# Altium schematic sheet Power.SchDoc(Power)
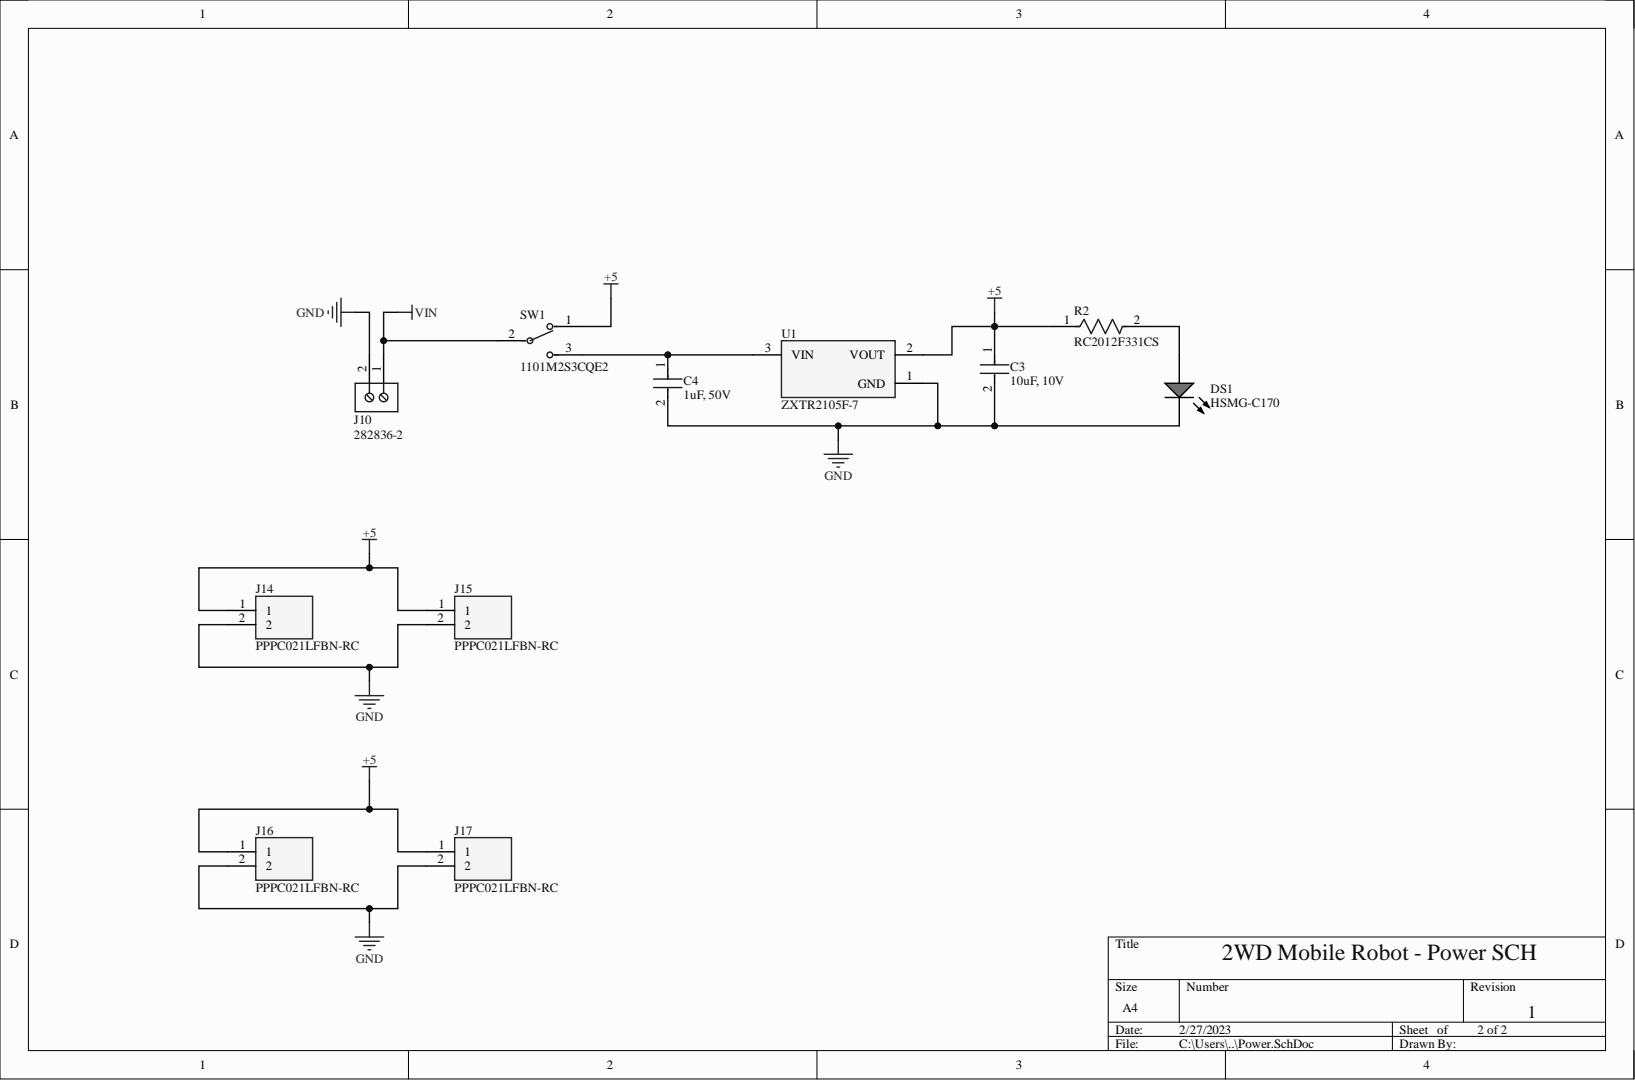
[diagram p0: Power.SchDoc]

## Parts
C3  10uF, 10V  [FP-C0805C-DG-MFG]
C4  1uF, 50V  [FP-C2012-125-0_2-IPC_A]
DS1  HSMG-C170  [AVAG-HSMX-C170_V]
J10  282836-2  [TECO-282836-2_V]
J14  PPPC021LFBN-RC  [RHDR2W80P0X254_1X2_558X254X850P]
J15  PPPC021LFBN-RC  [RHDR2W80P0X254_1X2_558X254X850P]
J16  PPPC021LFBN-RC  [RHDR2W80P0X254_1X2_558X254X850P]
J17  PPPC021LFBN-RC  [RHDR2W80P0X254_1X2_558X254X850P]
R2  RC2012F331CS  [FP-RC2012-MFG]
SW1  1101M2S3CQE2  [FP-1101M2S3CQE2-MFG]
U1  ZXTR2105F-7  [FP-SOT23-MFG]

## Nets
+5: C3.1 J14.1 J15.1 J16.1 J17.1 R2.1 SW1.1 U1.2
GND: C3.2 C4.2 DS1.2 J10.2 J14.2 J15.2 J16.2 J17.2 U1.1
VIN: J10.1 SW1.2
NetC4_1: C4.1 SW1.3 U1.3
NetDS1_1: DS1.1 R2.2

## Library data of the parts
C3 = CMP-2007-03243-2: CAP CER 10UF 10V X5R 0805; 3DS Part Number: 220-00001; Manufacturer: Kemet; Manufacturer Part Number: C0805C106K8PACTU; Manufacturer Product Number: C0805C106K8PAC7800; Material: Ceramic; Temperature Coefficient: 15%; Tolerance: 10%; Automotive: No; Capacitance: 10uF; Category: Cap; Component Kind: Standard; ComponentLink2Description: Datasheet; ComponentLink2URL: https://content.kemet.com/datasheets/KEM_C1006_X5R_SMD.pdf; Device Class L1: Passive Components; Device Class L2: Capacitors; Device Class L3: Ceramic Capacitors; Digikey Description: CAP CER 10UF 10V X5R 0805; Height: 1.4mm; Ipc Land Pattern Name: CAPC200125X125; Lead Free: Yes; Library Name: Altium Content Vault; Mouser Description: CAP CER 10UF 10V X5R 0805; Octopart: https://octopart.com/c0805c106k8pactu-kemet-98697; Package: C0805C-DG; RoHS: TRUE; Temperature: -55°C to +85°C; Temperature Characteristic: X5R; Temperature Range High: +85°C; Temperature Range Low: -55°C; Value: 10uF; Voltage: 10V; Voltage Rating: 10V
C4 = CMP-08246-005414-1: Multilayer Ceramic Capacitors 1μF ±10% 50V JB SMD 0805; Manufacturer Product Number: C2012JB1H105K125AB; Capacitance: 1uF; Case Code (Imperial): 0805; Case Code (Metric): 2012; Case/Package: 0805; Component Kind: Standard; Dielectric Material: Ceramic; Height: 1.25mm; Lead Free: Lead Free; Length: 0.079inch; Library Name: Altium Content Vault; Max Operating Temperature: 85°C; Min Operating Temperature: -25°C; Mount: Surface Mount; Package Quantity: 2000; Packaging: Tape and Reel; Resistor Type: Ceramic; RoHS Compliant: Yes; Series: C; Termination: SMD/SMT; Thickness: 0.057inch; Tolerance: 10%; Voltage Rating: 50V; Voltage Rating (DC): 50V; Width: 0.049inch
DS1 = CMP-2000-05062-1: Chip LED, Green, 2.2 V, -40 to 85 degC, 2-Pin SMD, Pb-Free, Tape and Reel; Manufacturer: Avago; Bulb Size: 1.25mmx1.4mm; Dominant Wavelength: 572nm; External Depth: 0.8mm; External Length / Height: 2mm; External Width: 1.25mm; Forward Current If: 20mA; Forward Voltage: 2.2V; Forward Voltage VF Max: 2.6V; LED Type: Standard; Lens Shape: Rectangular; Light Area Height: 1mm; Luminous Intensity: 15mcd; Luminous Intensity Min: 4mcd; No. of Pins: 2; Opto Mounting Orientation: Top; Peak Wavelength: 570nm; PIV Max: 5V; SVHC: NoSVHC(16-Jun-2014); Viewing Angle: 170deg; Component Kind: Standard; Datasheet URL: https://datasheet.ciiva.com/29280/180495-da-01-en-chip-led-hsmg-c170-gelb-gruen-29280971.pdf; Datasheet Version: Oct-08; Dominant Wavelength Typ: 572nm; Forward Current If Max: 20mA; LED Colour: Green; LED Mounting: SMD; Library Name: Altium Content Vault; Luminous Intensity Typ: 15mcd; Manufacturer URL: http://www.avagotech.com/; Mounting Type: SMD; MSL: MSL2A-4weeks; Operating Temperature Max: 85degC; Operating Temperature Min: -30degC; Operating Temperature Range: -30degCto+85degC; Opto Case Style: SMD; Package Description: 2-Pin Surface Mount Device, Body 2 x 1.25 mm, Height 0.8 mm; Package Reference: HSMX-C170; Packaging: CutTape; Peak Forward Current: 20mA; Storage Temperature Max: 85degC; Storage Temperature Min: -40degC; Voltage Vf Typ: 2.2V; Wavelength Typ: 570nm
J10 = CMP-1684-00017-1: Female Header, Pitch 5 mm, 1 x 2 Position, Height 10 mm, Tail Length 3.5 mm, Rohs, Bulk; Manufacturer: TE Connectivity; 3D Model: 282836-2.pdf; Clamp Material - Plating: Brass-NickelPlated; Current: 13.5A; Dynamic Catalog: BuchananSeries; Featured Product: EurostyleTerminalBlockConnectors; Mating Orientation: HorizontalwithBoard; Number of Levels: 1; Other Names: 5ULV-02B  A98076; Pitch: 0.197"(5.00mm); Positions Per Level: 2; Product Training Modules: Wire-to-BoardEurostyleTerminalBlocks; Screw Thread: M3; Torque - Screw: 0.68Nm(6Lb-In); Voltage: 300V; Wire Gauge: 16-30AWG; Wire Termination: Screw-RisingCageClamp; Category: Connectors,Interconnects; Color: Green; Component Kind: Standard; Contact Material - Plating: Brass-TinPlated; Datasheet URL: http://www.te.com.cn/commerce/DocumentDelivery/DDEController?Action=showdoc&DocId=Catalog+Section%7F1308389_EUROSTYLE_TERMINAL_BLOCKS%7F0607%7Fpdf%7FEnglish%7FENG_CS_1308389_EUROSTYLE_TERMINAL_BLOCKS_0607.pdf%7F282836-2; Family: TerminalBlocks-WiretoBoard; Features: Interlocking(Side); Housing Material: Polyamide(PA66),Nylon6/6; Library Name: Altium Content Vault; Manufacturer URL: http://www.te.com; Mounting Type: ThroughHole; Package Description: Female Header, Pitch 5 mm, 1 x 2 Position, Height 10 mm, Tail Length 3.5 mm; Package Reference: THD; Packaging: Bulk; RoHS Information: 282836-2StatementofCompliance; Screw Material - Plating: Brass-NickelPlated; Series: Buchanan; Standard Package: 300
J14-J17 = PPPC021LFBN-RC: Connector; Manufacturer_Name: Sullins; Component Kind: Standard; Datasheet Link: http://www.sullinscorp.com/drawings/78_P(N)PxCxxxLFBN-RC,_10492-H.pdf; Height: 8.5mm; Manufacturer_Part_Number: PPPC021LFBN-RC
R2 = CMP-13261-000745-1: Res Thick Film 0805 330Ω 1% 0.125W ±100ppm/°C Molded SMD T/R; Max Power Dissipation: 125mW; Resistance: 330R; Voltage Rating (DC): 150V; Case Code (Imperial): 0805; Case Code (Metric): 2012; Case/Package: 0805; Component Kind: Standard; Composition: Thick Film; Contact Plating: Tin; Depth: 1.25mm; Features: Moisture Resistant; Height: 0.024inch; Lead Free: Lead Free; Length: 0.079inch; Library Name: Altium Content Vault; Max Operating Temperature: 155°C; Military Standard: Not; Min Operating Temperature: -55°C; Number of Terminations: 2; Package Quantity: 1; Packaging: Tape and Reel; Power Rating: 125mW; Radiation Hardening: No; Temperature Coefficient: 100ppm/°C; Tolerance: 1%; Width: 0.049inch
SW1 = CMP-10038-000019-1: SWITCH SLIDE SPDT 6A 120V; Actuator Color: Black; Actuator Length: 5.08mm; Actuator Material: Nylon; Actuator Type: Standard; Approvals: UL; Body Material: Stainless Steel; Contact Resistance: 10mR; Electrical Life: 40000Cycles; Insulation Resistance: 1GR; Max Voltage Rating (AC): 250V; Max Voltage Rating (DC): 28V; Number of Poles: 1; Number of Positions: 3; Switch Function: On-On; Switch Travel: 2.16mm; Component Kind: Standard; Contact Current Rating: 6A; Contact Material: Copper; Contact Plating: Silver; Current Rating: 6A; Depth: 6.6mm; Flammability Rating: UL94 V-0; Housing Material: Stainless Steel; Lead Free: Lead Free; Length: 12.7mm; Library Name: Altium Content Vault; Max Current Rating: 6A; Max Operating Temperature: 65°C; Min Operating Temperature: -30°C; Mount: Through Hole; Orientation: Vertical; Package Quantity: 1; Packaging: Bulk; REACH SVHC: No SVHC; Series: 1000; Termination: PC Pins; Throw Configuration: SPDT; Voltage Rating (AC): 120V; Voltage Rating (DC): 28V
U1 = CMP-12756-000045-1: IC REG LINEAR 5V 89MA SOT23; Max Input Voltage: 60V; Max Power Dissipation: 625mW; Min Input Voltage: 7V; Number of Outputs: 1; Output Current: 15mA; Output Type: Fixed; Case/Package: TO-236-3; Component Kind: Standard; Lead Free: Lead Free; Library Name: Altium Content Vault; Max Operating Temperature: 150°C; Min Operating Temperature: -65°C; Mount: Surface Mount; Number of Pins: 23; Number of Regulators: 1; Output Voltage: 5V; Package Quantity: 3000; Packaging: Tape and Reel; RoHS Compliant: Yes
